# Altium schematic sheet OpenSwitch.SchDoc(OpenSwitch)
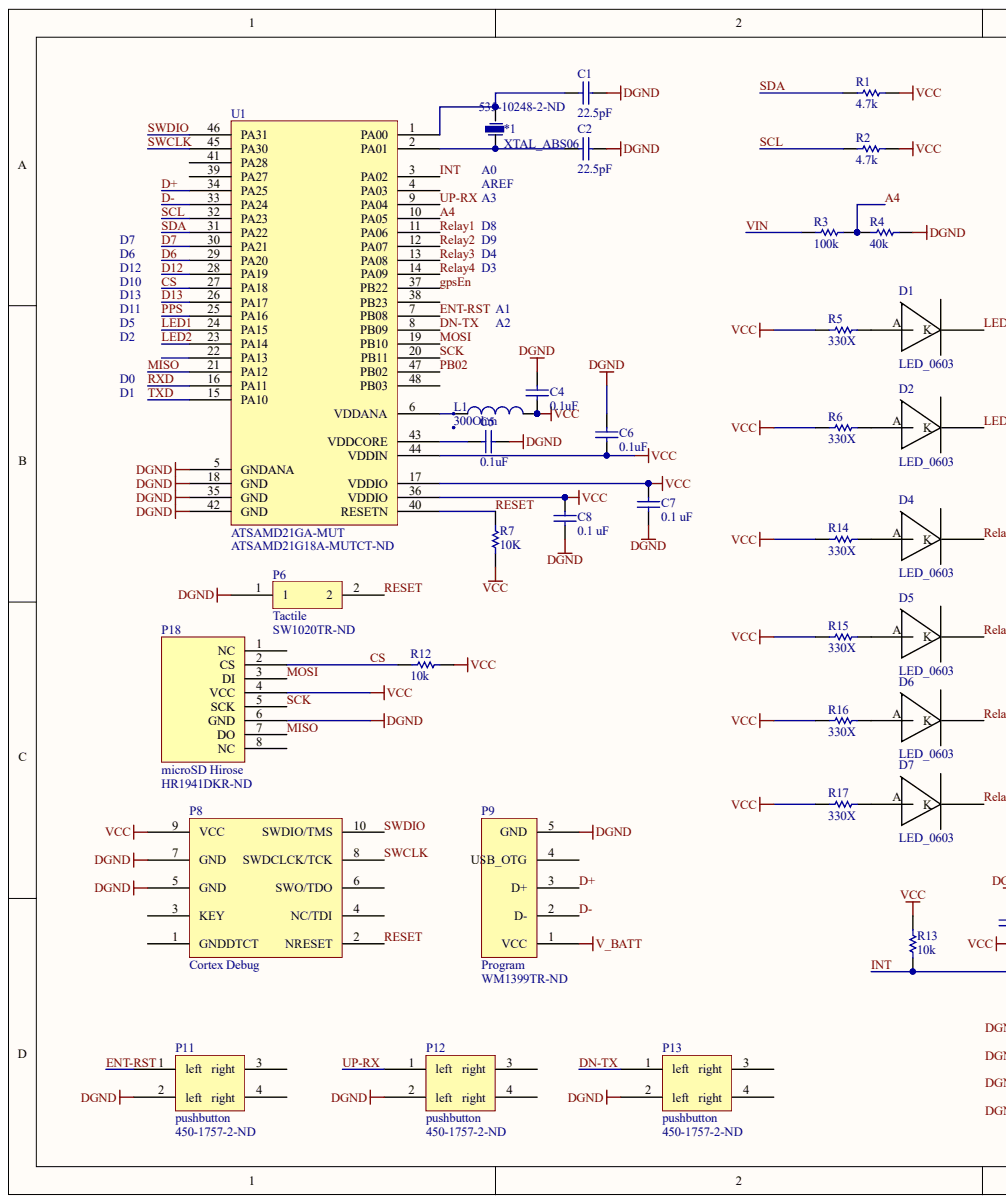
[diagram p0: OpenSwitch.SchDoc, left]
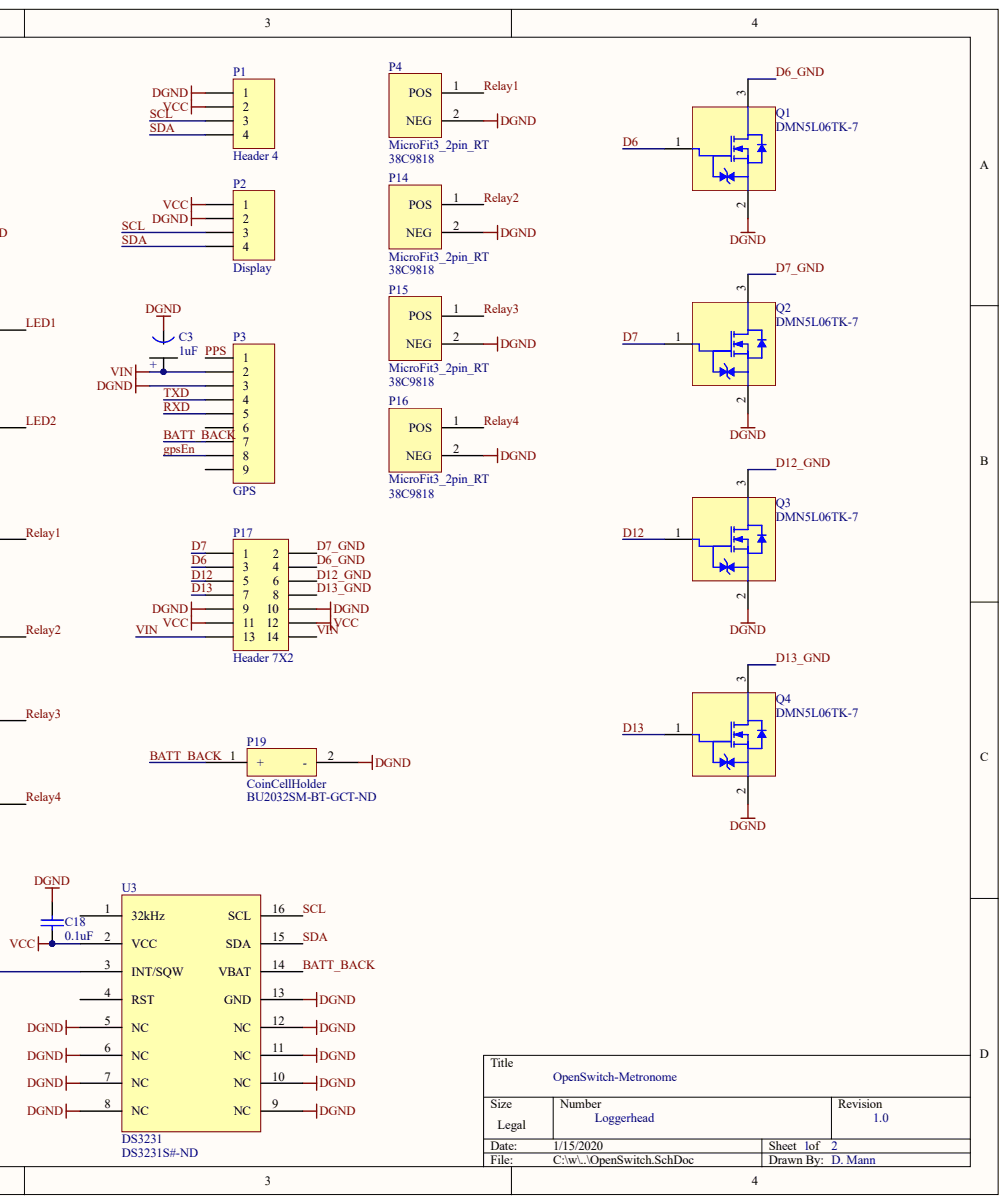
[diagram p1: OpenSwitch.SchDoc, right]

## Parts
*1  XTAL_ABS06  Supplier Part Number 1: 535-10248-2-ND
C1  22.5pF
C2  22.5pF
C3  1uF
C4  0.1uF
C5  0.1uF
C6  0.1uF
C7  0.1 uF
C8  0.1 uF
C18  0.1uF
D1  LED_0603
D2  LED_0603
D4  LED_0603
D5  LED_0603
D6  LED_0603
D7  LED_0603
L1  300Ohm
P1  Header 4
P2  Display
P3  GPS
P4  MicroFit3_2pin_RT
P6  Tactile
P8  Cortex Debug
P9  Program  Supplier Part Number 1: WM1399TR-ND
P11  pushbutton  Supplier Part Number 1: 450-1757-2-ND
P12  pushbutton  Supplier Part Number 1: 450-1757-2-ND
P13  pushbutton  Supplier Part Number 1: 450-1757-2-ND
P14  MicroFit3_2pin_RT  Supplier Part Number 1: 38C9818
P15  MicroFit3_2pin_RT  Supplier Part Number 1: 38C9818
P16  MicroFit3_2pin_RT  Supplier Part Number 1: 38C9818
P17  Header 7X2
P18  microSD Hirose  Supplier Part Number 1: HR1941DKR-ND
P19  CoinCellHolder  Supplier Part Number 1: BU2032SM-BT-GCT-ND
Q1  DMN5L06TK-7
Q2  DMN5L06TK-7
Q3  DMN5L06TK-7
Q4  DMN5L06TK-7
R1  4.7k
R2  4.7k
R3  100k
R4  40k
R5  330X
R6  330X
R7  10K
R12  10k
R13  10k
R14  330X
R15  330X
R16  330X
R17  330X
U1  ATSAMD21GA-MUT  Supplier Part Number 1: ATSAMD21G18A-MUTCT-ND
U3  DS3231  Supplier Part Number 1: DS3231S#-ND

## Nets
DGND: C1.1 C2.1 C3.1 C4.2 C5.2 C6.2 C7.2 C8.2 C18.2 P1.1 P2.2 P3.3 P4.2 P6.1 P8.5(GND) P8.7(GND) P9.5(GND) P11.2(left) P12.2(left) P13.2(left) P14.2 P15.2 P16.2 P17.9 P17.10 P18.6(GND) P19.2 Q1.2 Q2.2 Q3.2 Q4.2 R4.1 U1.5(GNDANA) U1.18(GND) U1.35(GND) U1.42(GND) U3.5(NC) U3.6(NC) U3.7(NC) U3.8(NC) U3.9(NC) U3.10(NC) U3.11(NC) U3.12(NC) U3.13(GND)
V_BATT: P9.1(VCC)
VCC: C4.1 C6.1 C7.1 C8.1 C18.1 L1.2 P1.2 P2.1 P8.9(VCC) P17.11 P17.12 P18.4(VCC) R1.2 R2.2 R5.1 R6.1 R7.1 R12.1 R13.1 R14.1 R15.1 R16.1 R17.1 U1.17(VDDIO) U1.36(VDDIO) U1.44(VDDIN) U3.2(VCC)
A4: R3.1 R4.2 U1.10(PA05)
BATT_BACK: P3.7 P19.1 U3.14(VBAT)
CS: P18.2(CS) R12.2 U1.27(PA18)
D6: P17.3 Q1.1 U1.29(PA20)
D6_GND: P17.4 Q1.3
D7: P17.1 Q2.1 U1.30(PA21)
D7_GND: P17.2 Q2.3
D12: P17.5 Q3.1 U1.28(PA19)
D12_GND: P17.6 Q3.3
D13: P17.7 Q4.1 U1.26(PA17)
D13_GND: P17.8 Q4.3
D+: P9.3(D+) U1.34(PA25)
D-: P9.2(D-) U1.33(PA24)
DN-TX: P13.1(left) U1.8(PB09)
ENT-RST: P11.1(left) U1.7(PB08)
GPSEN: P3.8 U1.37(PB22)
INT: R13.2 U1.3(PA02) U3.3(INT/SQW)
LED1: D1.K U1.24(PA15)
LED2: D2.K U1.23(PA14)
MISO: P18.7(DO) U1.21(PA12)
MOSI: P18.3(DI) U1.19(PB10)
PB02: U1.47(PB02)
PPS: P3.1 U1.25(PA16)
RELAY1: D4.K P4.1 U1.11(PA06)
RELAY2: D5.K P14.1 U1.12(PA07)
RELAY3: D6.K P15.1 U1.13(PA08)
RELAY4: D7.K P16.1 U1.14(PA09)
RESET: P6.2 P8.2(NRESET) R7.2 U1.40(RESETN)
RXD: P3.5 U1.16(PA11)
SCK: P18.5(SCK) U1.20(PB11)
SCL: P1.3 P2.3 R2.1 U1.32(PA23) U3.16(SCL)
SDA: P1.4 P2.4 R1.1 U1.31(PA22) U3.15(SDA)
SWCLK: P8.8(SWDCLCK/TCK) U1.45(PA30)
SWDIO: P8.10(SWDIO/TMS) U1.46(PA31)
TXD: P3.4 U1.15(PA10)
UP-RX: P12.1(left) U1.9(PA04)
VIN: C3.2 P3.2 P17.13 P17.14 R3.2
Net*1_1: *1.1 C2.2 U1.2(PA01)
Net*1_2: *1.2 C1.2 U1.1(PA00)
NetC5_1: C5.1 U1.43(VDDCORE)
NetD1_A: D1.A R5.2
NetD2_A: D2.A R6.2
NetD4_A: D4.A R14.2
NetD5_A: D5.A R15.2
NetD6_A: D6.A R16.2
NetD7_A: D7.A R17.2
NetL1_1: L1.1 U1.6(VDDANA)

Not connected: P3 6 9; P8 1(GNDDTCT) 3(KEY) 4(NC/TDI) 6(SWO/TDO); P9 4; P11 3(right) 4(right); P12 3(right) 4(right); P13 3(right) 4(right); P18 1(NC) 8(NC); U1 4(PA03) 22(PA13) 38(PB23) 39(PA27) 41(PA28) 48(PB03); U3 1(32kHz) 4(RST)

## Library data of the parts
C1 C2 = (no symbol name): Note: Footprint corresponds to dimensions for bulk cassette packaging. This case size has tightened tolerances.; Value: 12.5; Published: 17-Jul-2002; LatestRevisionDate: 19-Mar-2004; LatestRevisionNote: Silkscreen size reduced.; DatasheetDocument: Mar-2001; PackageDocument: Mar-2001; PackageReference: 0402; Code_JEITA: 0402; Code_IPC: 1005 [0402]; ComponentLink1Description: Manufacturer Link; ComponentLink1URL: http://www.kemet.com/; ComponentLink2Description: Datasheet; ComponentLink2URL: http://www.kemet.com/kemet/web/homepage/kechome.nsf/vapubfilesname/F3102TA.pdf/$file/F3102TA.pdf; Publisher: Altium Limited
C4-C6 C18 = (no symbol name): Note: Footprint corresponds to dimensions for bulk cassette packaging. This case size has tightened tolerances.; Published: 17-Jul-2002; LatestRevisionDate: 19-Mar-2004; LatestRevisionNote: Silkscreen size reduced.; DatasheetDocument: Mar-2001; PackageDocument: Mar-2001; PackageReference: 0402; Code_JEITA: 0402; Code_IPC: 1005 [0402]; ComponentLink1Description: Manufacturer Link; ComponentLink1URL: http://www.kemet.com/; ComponentLink2Description: Datasheet; ComponentLink2URL: http://www.kemet.com/kemet/web/homepage/kechome.nsf/vapubfilesname/F3102TA.pdf/$file/F3102TA.pdf; Value: 0.1uF; Publisher: Altium Limited
C7 C8 = (no symbol name): Note: Footprint corresponds to dimensions for bulk cassette packaging. This case size has tightened tolerances.; Value: 0.1uF; Published: 17-Jul-2002; LatestRevisionDate: 19-Mar-2004; LatestRevisionNote: Silkscreen size reduced.; DatasheetDocument: Mar-2001; PackageDocument: Mar-2001; PackageReference: 0402; Code_JEITA: 0402; Code_IPC: 1005 [0402]; ComponentLink1Description: Manufacturer Link; ComponentLink1URL: http://www.kemet.com/; ComponentLink2Description: Datasheet; ComponentLink2URL: http://www.kemet.com/kemet/web/homepage/kechome.nsf/vapubfilesname/F3102TA.pdf/$file/F3102TA.pdf; Publisher: Altium Limited
L1 Q1-Q4 = (no symbol name): Fabrication Tested: NO; Supplier 1: Digi-Key; Footprint Verified By: *; Schematic Verified By: *; Vendor Info Verified By: *
  L1: Value: 300Ohm; HelpURL: http://www.yuden.co.jp/ut/product/pdf/mlci08_e.pdf; Mass (place origin at center): *; Supplier Part Number 1: 587-1932-1-ND; Supplier 2: Newark; Supplier Part Number 2: 73M9170; Creator and Date: Design Engineer's Name
  Q1-Q4: Supplier Part Number 1: DMN5L06TKDICT-ND; HelpURL: http://www.diodes.com/datasheets/ds30926.pdf; Creator and Date: Will Flanery 2010-09-02
*1 C3 D1 D2 D4-D7 P1-P4 P6 P8 P9 P11-P19 R1-R7 R12-R17 U1 U3 = (no symbol name)
  *1 P9 P11-P13 P18 P19 U1 U3: Supplier 1: Digi-Key
  C3: Value: 1uF
  P1-P3 P17: LatestRevisionDate: 17-Jul-2002; LatestRevisionNote: Re-released for DXP Platform.
  P4: Supplier 1: Newark; Supplier Part Number 1: 38C9818
  P6: Supplier 1: Digi-Key; Supplier Part Number 1: SW1020TR-ND
  P14-P16: Supplier 1: Newark
  R1-R7 R12-R17: Published: 17-Dec-2003; LatestRevisionDate: 19-Mar-2004; LatestRevisionNote: Silkscreen size reduced.; PackageDocument: Mar-2003; PackageReference: 3-0603; Code_IPC: 1608 [0603]; ComponentLink1Description: Manufacturer Link; ComponentLink1URL: http://www.panasonic.com/industrial/components/resistive_products.htm; ComponentLink2Description: Datasheet; ComponentLink2URL: http://www.panasonic.com/industrial/components/pdf/AOA0000CE2.pdf
  P1-P3 P17 R1-R7 R12-R17: Publisher: Altium Limited
